# Altium schematic sheet Э3("All Documents",Physical)
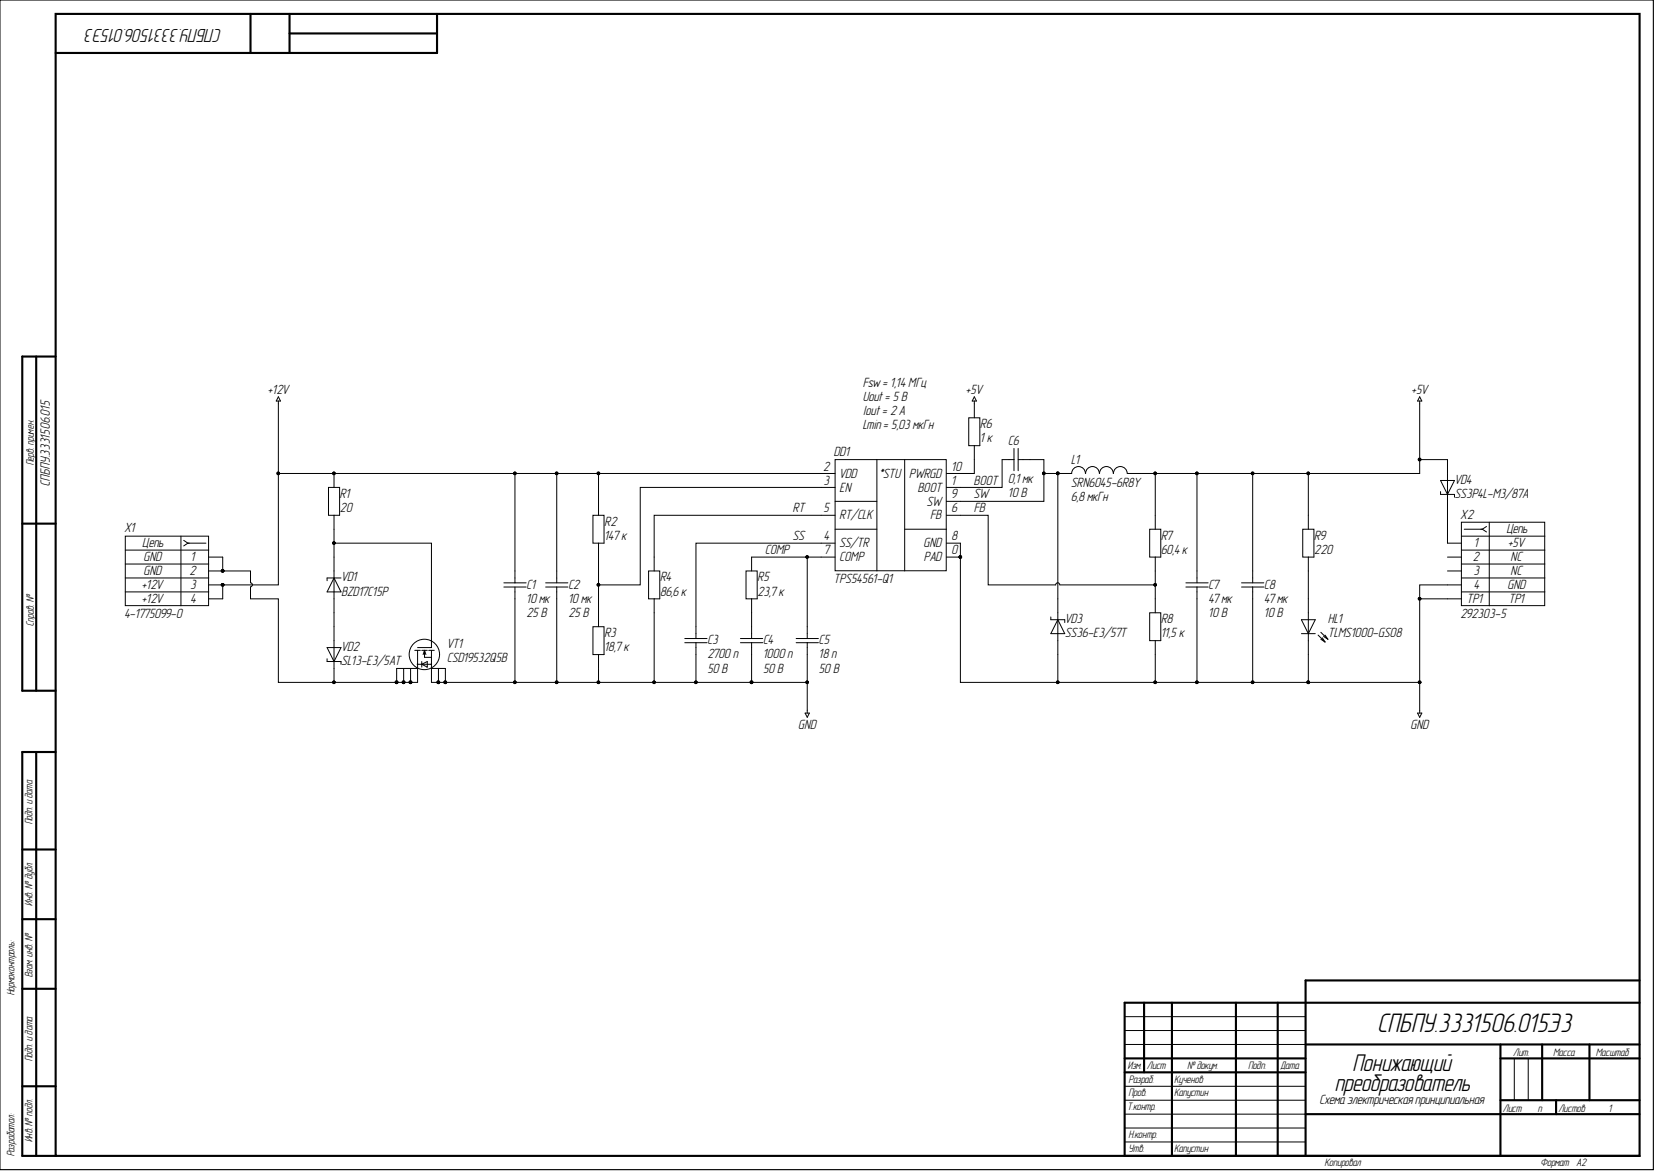
[diagram p0: Э3("All Documents",Physical)]

## Parts
C1  10 мк  [C0805]
C2  10 мк  Номинальное напряжение: 25 В  [C0805]
C3  2700 п  Номинальное напряжение: 50 В  [C0805]
C4  1000 п  Номинальное напряжение: 50 В  [C0402]
C5  18 п  Номинальное напряжение: 50 В  [C0805]
C6  0,1 мк  Номинальное напряжение: 10 В  [C0402]
C7  47 мк  Номинальное напряжение: 10 В  [C1210]
C8  47 мк  Номинальное напряжение: 10 В  [C1210]
DD1  TPS54561-Q1  [WSON-10]
HL1  TLMS1000-GS08  [HL0603]
L1  SRN6045-6R8Y  Номинал: 6,8 мкГн  [SRN6045-6R8Y]
R1  20  [R0402]
R2  147 к  [R0603]
R3  18,7 к  [R0402]
R4  86,6 к  [R0201]
R5  23,7 к  [R0201]
R6  1 к  [R0402]
R7  60,4 к  [R0402]
R8  11,5 к  [R0402]
R9  220  [R0603]
VD1  BZD17C15P  [DO-219AB]
VD2  SL13-E3/5AT  [DO-214AC]
VD3  SS36-E3/57T  [DO-214AB]
VD4  SS3P4L-M3/87A  [TO-277A]
VT1  CSD19532Q5B  [SON-8]
X1  4-1775099-0  Contact_4: +12V  Contact_2: GND  [4-1775099-0]
X2  292303-5  Contact_4: GND  Contact_3: NC  Contact_1: +5V  [292303-5]

## Nets
+5V: C7.1 C8.1 L1.2 R6.2 R7.2 R9.2 VD4.2
+12V: C1.2 C2.1 DD1.2(VDD) R1.2 R2.2 X1.3 X1.4
GND: C1.1 C2.2 C3.2 C4.2 C5.2 C7.2 C8.2 DD1.0(PAD) DD1.8(GND) HL1.1 R3.1 R4.1 R8.1 VD3.2 VT1.S X2.4 X2.TP1
BOOT: C6.2 DD1.1(BOOT)
COMP: C5.1 DD1.7(COMP) R5.2
FB: DD1.6(FB) R7.1 R8.2
RT: DD1.5(RT/CLK) R4.2
SS: C3.1 DD1.4(SS/TR)
SW: C6.1 DD1.9(SW) L1.1 VD3.1
NetC4_1: C4.1 R5.1
NetDD1_3: DD1.3(EN) R2.1 R3.2
NetDD1_10: DD1.10(PWRGD) R6.1
NetHL1_2: HL1.2 R9.1
NetR1_1: R1.1 VD1.1 VT1.G
NetVD1_2: VD1.2 VD2.2
NetVD2_1: VD2.1 VT1.D X1.1 X1.2
NetVD4_1: VD4.1 X2.1
NetX2_2: X2.2
NetX2_3: X2.3

## Library data of the parts
C1 = c0119: =Описание; Фамилия: Кученов; Температурный коэффициент емкости: X5R; Рабочие температуры: от –55 °С до +85 °С; Производитель: Murata Manufacturing Co., Ltd.; Отклонение: ±20 %; Описание: Конденсатор чип 0805 10 мкФ 25 В ±20 %; Номинальное напряжение: 25 В; Наименование: GRM21BR61E106MA73L; Корпус: 0805; Database Table Name: Конденсаторы$; Номинал: 10 мк; Напряжение: 25 В; ID: c0119; HelpURL: https://search.murata.co.jp/Ceramy/image/img/A01X/G101/ENG/GRM21BR61E106MA73-01.pdf; Description: Конденсатор чип 0805 10 мкФ 25 В ±20 %; Component Kind: Standard; Library Name: Database_Students.DbLib
C2 = c0119: =Описание; Фамилия: Кученов; Температурный коэффициент емкости: X5R; Рабочие температуры: от –55 °С до +85 °С; Производитель: Murata Manufacturing Co., Ltd.; Отклонение: ±20 %; Описание: Конденсатор чип 0805 10 мкФ 25 В ±20 %; Наименование: GRM21BR61E106MA73L; Корпус: 0805; Database Table Name: Конденсаторы$; Номинал: 10 мк; Напряжение: 25 В; ID: c0119; HelpURL: https://search.murata.co.jp/Ceramy/image/img/A01X/G101/ENG/GRM21BR61E106MA73-01.pdf; Description: Конденсатор чип 0805 10 мкФ 25 В ±20 %; Component Kind: Standard; Library Name: Database_Students.DbLib
C3 = c0120: =Описание; Фамилия: Кученов; Температурный коэффициент емкости: C0G; Рабочие температуры: от –55 °С до +125 °С; Производитель: TDK Corporation; Отклонение: ±5 %; Описание: Конденсатор чип 0805 2,7 нФ 50 В ±5 %; Наименование: C2012C0G1H272J060AA; Корпус: 0805; Database Table Name: Конденсаторы$; Номинал: 2700 п; Напряжение: 50 В; ID: c0120; HelpURL: https://product.tdk.com/en/search/capacitor/ceramic/mlcc/info?part_no=C2012C0G1H272J060AA; Description: Конденсатор чип 0805 2,7 нФ 50 В ±5 %; Component Kind: Standard; Library Name: Database_Students.DbLib
C4 = c0121: =Описание; Фамилия: Кученов; Температурный коэффициент емкости: C0G; Рабочие температуры: от –55 °С до +125 °С; Производитель: Murata Manufacturing Co., Ltd.; Отклонение: ±5 %; Описание: Конденсатор чип 0402 1 нФ 50 В ±5 %; Наименование: GRM1555C1H102JA01J; Корпус: 0402; Database Table Name: Конденсаторы$; Номинал: 1000 п; Напряжение: 50 В; ID: c0121; HelpURL: https://www.murata.com/en-eu/products/productdetail?partno=GRM1555C1H102JA01%23; Description: Конденсатор чип 0402 1 нФ 50 В ±5 %; Component Kind: Standard; Library Name: Database_Students.DbLib
C5 = c0122: =Описание; Фамилия: Кученов; Температурный коэффициент емкости: C0G; Рабочие температуры: от –55 °С до +125 °С; Производитель: Samsung electro-mechanics; Отклонение: ±5 %; Описание: Конденсатор чип 0805 18 пФ 50 В ±5 %; Наименование: CL21C180JBANNNC; Корпус: 0805; Database Table Name: Конденсаторы$; Номинал: 18 п; Напряжение: 50 В; ID: c0122; HelpURL: https://datasheet.lcsc.com/szlcsc/1810191212_Samsung-Electro-Mechanics-CL21C180JBANNNC_C170109.pdf; Description: Конденсатор чип 0805 18 пФ 50 В ±5 %; Component Kind: Standard; Library Name: Database_Students.DbLib
C6 = c0123: =Описание; Фамилия: Кученов; Температурный коэффициент емкости: X7R; Рабочие температуры: от –55 °С до +125 °С; Производитель: Murata Manufacturing Co., Ltd.; Отклонение: ±15 %; Описание: Конденсатор чип 0402 100 нФ 10 В ±15 %; Наименование: GRM155R71A104KA01D; Корпус: 0402; Database Table Name: Конденсаторы$; Номинал: 0,1 мк; Напряжение: 10 В; ID: c0123; HelpURL: https://www.murata.com/products/productdetail?partno=GRM155R71A104KA01%23; Description: Конденсатор чип 0402 100 нФ 10 В ±15 %; Component Kind: Standard; Library Name: Database_Students.DbLib
C7 C8 = c0124: =Описание; Фамилия: Кученов; Температурный коэффициент емкости: X7R; Рабочие температуры: от –55 °С до +125 °С; Производитель: Murata Manufacturing Co., Ltd.; Отклонение: ±15 %; Описание: Конденсатор чип 1210 47 мкФ 10 В ±15 %; Наименование: GRM32ER71A476KE15; Корпус: 1210; Database Table Name: Конденсаторы$; Номинал: 47 мк; Напряжение: 10 В; ID: c0124; HelpURL: https://www.murata.com/en-global/products/productdetail?partno=GRM32ER71A476KE15%23; Description: Конденсатор чип 1210 47 мкФ 10 В ±15 %; Component Kind: Standard; Library Name: Database_Students.DbLib
DD1 = dd0009: =Описание; Частота переключения: от 100 кГц до 2,5 МГц; Фамилия: Кученов; Рабочие температуры: от -40 °С до +125 °С; Производитель: Texas Instruments; Описание: Понижающий преобразователь напряжения 60 В и 5 А; Коммутируемый ток: до 5 А; Выходное напряжение: от 0,8 В до 59 В; Входное напряжение: от 4,5 В до 60 В; Наименование: TPS54561-Q1; Корпус: WSON-10; Library Name: TPS54561.SchLib; ID: dd0009; HelpURL: https://www.ti.com/lit/ds/symlink/tps54561-q1.pdf?ts=1610208376292&ref_url=https%253A%252F%252Fwww.ti.com%252Fproduct%252FTPS54561-Q1; Description: Понижающий преобразователь напряжения 60 В и 5 А; Component Kind: Standard
HL1 = hl0001: =Описание; Цвет: Red; Фамилия: Ларионов; Рассеиваемая мощность: 40 мВт; Рабочие температуры: от –40 °С до +100 °С; Производитель: Vishay Intertechnology, Inc.; Описание: Светодиод чип 0603 красный; Номинальный прямой ток: 15 мА; Номинальное падение напряжения: 1,8 В; Номинальная сила света: 4 мкд; Номинальная длина волны: 628 нм; Корпус: 0603; Database Table Name: Светодиоды$; Наименование: TLMS1000-GS08; ID: hl0001; HelpURL: https://www.vishay.com/leds/list/product-83172/; Description: Светодиод чип 0603 красный; Component Kind: Standard; Library Name: Database_Students.DbLib
L1 = l0006: =Описание; Фамилия: Кученов; Ток насыщения: 3,8 А; Резонансная частота: 20МГц; Рабочие температуры: от –40 °С до +125 °С; Рабочее напряжение: не указано; Производитель: Bourns, Inc.; Отклонение: ±30 %; Описание: Катушка индуктивности 6,8 мкГн ±30 %; Номинальный ток (при нагреве на 20 °С/40 °С): 2,8 А; Номинальное активное сопротивление: 47,3 мОм; Корпус: 6,0 x 6,0 x 4,5; Database Table Name: Катушки индуктивности$; Наименование: SRN6045-6R8Y; Максимальное активное сопротивление: 47,3 мОм; Индуктивность: 6,8 мкГн; ID: l0006; HelpURL: https://www.bourns.com/data/global/pdfs/SRN6045.pdf; Description: Катушка индуктивности 6,8 мкГн ±30 %; Component Kind: Standard; Library Name: Database_Students.DbLib
R1 = r0135: =Описание; Фамилия: Кученов; Рабочие температуры: от –55 °С до +155 °С; Производитель: YAGEO Corp.; Отклонение: ±1 %; Описание: Резистор чип 0402 20 Ом ±1 % 0,0625 Вт; Номинальный ток: 1 А; Номинальное напряжение: 50 В; Наименование: RC0402FR-0718K7L; Корпус: 0402; Database Table Name: Резисторы$; Номинал: 20; ID: r0135; HelpURL: https://www.yageo.com/upload/media/product/productsearch/datasheet/rchip/PYu-RC_Group_51_RoHS_L_11.pdf; Description: Резистор чип 0402 20 Ом ±1 % 0,0625 Вт; Component Kind: Standard; Library Name: Database_Students.DbLib
R2 = r0100: =Описание; Фамилия: Жернаков; Рабочие температуры: от –55 °С до +155 °С; Производитель: YAGEO Corp.; Отклонение: ±1 %; Описание: Резистор чип 0603 147 кОм ±1 % 0,1 Вт; Номинальный ток: 1 А; Номинальное напряжение: 75 В; Наименование: RC0603FR-07147KL; Корпус: 0603; Database Table Name: Резисторы$; Номинал: 147 к; ID: r0100; HelpURL: https://www.yageo.com/upload/media/product/productsearch/datasheet/rchip/PYu-RC_Group_51_RoHS_L_11.pdf; Description: Резистор чип 0603 147 кОм ±1 % 0,1 Вт; Component Kind: Standard; Library Name: Database_Students.DbLib
R3 = r0134: =Описание; Фамилия: Кученов; Рабочие температуры: от –55 °С до +155 °С; Производитель: YAGEO Corp.; Отклонение: ±1 %; Описание: Резистор чип 0402 18,7 кОм ±1 % 0,0625 Вт; Номинальный ток: 1 А; Номинальное напряжение: 50 В; Наименование: RC0402FR-0718K7L; Корпус: 0402; Database Table Name: Резисторы$; Номинал: 18,7 к; ID: r0134; HelpURL: https://www.yageo.com/upload/media/product/productsearch/datasheet/rchip/PYu-RC_Group_51_RoHS_L_11.pdf; Description: Резистор чип 0402 18,7 кОм ±1 % 0,0625 Вт; Component Kind: Standard; Library Name: Database_Students.DbLib
R4 = r0129: =Описание; Фамилия: Кученов; Рабочие температуры: от –55 °С до +125 °С; Производитель: YAGEO Corp.; Отклонение: ±1 %; Описание: Резистор чип 0201 86,6 кОм ±1 % 0,05 Вт; Номинальный ток: 0.5 А; Номинальное напряжение: 25 В; Наименование: RC0201FR-0786K6L; Корпус: 0201; Database Table Name: Резисторы$; Номинал: 86,6 к; ID: r0129; HelpURL: https://www.yageo.com/upload/media/product/productsearch/datasheet/rchip/PYu-RC_Group_51_RoHS_L_11.pdf; Description: Резистор чип 0201 86,6 кОм ±1 % 0,05 Вт; Component Kind: Standard; Library Name: Database_Students.DbLib
R5 = r0131: =Описание; Фамилия: Кученов; Рабочие температуры: от –55 °С до +125 °С; Производитель: YAGEO Corp.; Отклонение: ±1 %; Описание: Резистор чип 0201 23,7 кОм ±1 % 0,05 Вт; Номинальный ток: 0.5 А; Номинальное напряжение: 25 В; Наименование: RC0201FR-0723K7L; Корпус: 0201; Database Table Name: Резисторы$; Номинал: 23,7 к; ID: r0131; HelpURL: https://www.yageo.com/upload/media/product/productsearch/datasheet/rchip/PYu-RC_Group_51_RoHS_L_11.pdf; Description: Резистор чип 0201 23,7 кОм ±1 % 0,05 Вт; Component Kind: Standard; Library Name: Database_Students.DbLib
R6 = r0130: =Описание; Фамилия: Кученов; Рабочие температуры: от –55 °С до +155 °С; Производитель: Vishay Intertechnology, Inc.; Отклонение: ±1 %; Описание: Резистор чип 0402 1 кОм ±1 % 0,063 Вт; Номинальный ток: 1.5 А; Номинальное напряжение: 50 В; Наименование: CRCW04021K00FKED; Корпус: 0402; Database Table Name: Резисторы$; Номинал: 1 к; ID: r0130; HelpURL: https://www.vishay.com/docs/20035/dcrcwe3.pdf; Description: Резистор чип 0402 1 кОм ±1 % 0,063 Вт; Component Kind: Standard; Library Name: Database_Students.DbLib
R7 = r0132: =Описание; Фамилия: Кученов; Рабочие температуры: от –55 °С до +155 °С; Производитель: Vishay Intertechnology, Inc.; Отклонение: ±1 %; Описание: Резистор чип 0402 60,4 кОм ±1 % 0,063 Вт; Номинальный ток: 1.5 А; Номинальное напряжение: 50 В; Наименование: CRCW040260K4FKED; Корпус: 0402; Database Table Name: Резисторы$; Номинал: 60,4 к; ID: r0132; HelpURL: https://www.vishay.com/docs/20035/dcrcwe3.pdf; Description: Резистор чип 0402 60,4 кОм ±1 % 0,063 Вт; Component Kind: Standard; Library Name: Database_Students.DbLib
R8 = r0133: =Описание; Фамилия: Кученов; Рабочие температуры: от –55 °С до +155 °С; Производитель: Vishay Intertechnology, Inc.; Отклонение: ±1 %; Описание: Резистор чип 0402 11,5 кОм ±1 % 0,063 Вт; Номинальный ток: 1.5 А; Номинальное напряжение: 50 В; Наименование: CRCW040211K5FKED; Корпус: 0402; Database Table Name: Резисторы$; Номинал: 11,5 к; ID: r0133; HelpURL: https://www.vishay.com/docs/20035/dcrcwe3.pdf; Description: Резистор чип 0402 11,5 кОм ±1 % 0,063 Вт; Component Kind: Standard; Library Name: Database_Students.DbLib
R9 = r0009: =Описание; Фамилия: Ларионов; Рабочие температуры: от -55 °С до +155 °С; Производитель: YAGEO Corp.; Отклонение: ±1 %; Описание: Резистор чип 0603 220 Ом ±1 % 0,1 Вт; Номинальный ток: 1 А; Номинальное напряжение: 75 В; Наименование: RC0603FR-07220RL; Корпус: 0603; Database Table Name: Резисторы$; Номинал: 220; ID: r0009; HelpURL: https://www.yageo.com/upload/media/product/productsearch/datasheet/rchip/PYu-RC_Group_51_RoHS_L_11.pdf; Description: Резистор чип 0603 220 Ом ±1 % 0,1 Вт; Component Kind: Standard; Library Name: Database_Students.DbLib
VD1 = vd0016: =Описание; Фамилия: Жернаков; Разброс напряжения стабилизации: 13,8...15,6 В; Рабочие температуры: от –55 °С до +150 °С; Производитель: Vishay Intertechnology, Inc.; Описание: Стабилитрон 15 В; Номинальный ток стабилизации: 50 мА; Номинальное напряжение стабилизации: 15 В; Database Table Name: Стабилитроны$; Наименование: BZD17C15P; Корпус: DO-219AB; ID: vd0016; HelpURL: https://www.vishay.com/product?docid=85161; Description: Стабилитрон 15 В; Component Kind: Standard; Library Name: Database_Students.DbLib
VD2 = vd0002: =Описание; Фамилия: Ларионов; Рабочие температуры: от –55 °С до +125 °С; Производитель: Vishay Intertechnology, Inc.; Пиковое прямое напряжение: 0,445 В; Описание: Диод Шоттки 30 В 1,5 А; Макс допустимый средний прямой ток: 1,5 А; Импульсное обратное напряжение: 30 В; Database Table Name: Диоды$; Наименование: SL13-E3/5AT; Корпус: DO-214AC; ID: vd0002; HelpURL: https://www.vishay.com/product?docid=88740; Description: Диод Шоттки 30 В 1,5 А; Component Kind: Standard; Library Name: Database_Students.DbLib
VD3 = vd0004: =Описание; Фамилия: Жернаков; Рабочие температуры: от –55 °С до +150 °С; Производитель: Vishay Intertechnology, Inc.; Пиковое прямое напряжение: 0,75 В; Описание: Диод Шоттки 60 В 3 А; Макс допустимый средний прямой ток: 3 А; Импульсное обратное напряжение: 60 В; Database Table Name: Диоды$; Наименование: SS36-E3/57T; Корпус: DO-214AB; ID: vd0004; HelpURL: https://www.vishay.com/product?docid=88751; Description: Диод Шоттки 60 В 3 А; Component Kind: Standard; Library Name: Database_Students.DbLib
VD4 = vd0003: =Описание; Фамилия: Ларионов; Рабочие температуры: от –55 °С до +150 °С; Производитель: Vishay Intertechnology, Inc.; Пиковое прямое напряжение: 0,47 В; Описание: Диод Шоттки 40 В 3 А; Макс допустимый средний прямой ток: 3 А; Импульсное обратное напряжение: 40 В; Database Table Name: Диоды$; Наименование: SS3P4L-M3/87A; Корпус: TO-277A; ID: vd0003; HelpURL: https://www.vishay.com/diodes/list/product-88986/; Description: Диод Шоттки 40 В 3 А; Component Kind: Standard; Library Name: Database_Students.DbLib
VT1 = vt0001: =Описание; Фамилия: Жернаков; Ток стока: 17 А; Сопротивление сток-исток: 0,0057 Ом; Рабочие температуры: от -55 °С до +150 °С; Производитель: Texas Instruments; Описание: Транзистор полевой N-канальный 100 В 17 А; Напряжение сток-исток: 100 В; Напряжение затвор-исток: ±20 В; Database Table Name: Транзисторы$; Наименование: CSD19532Q5B; Корпус: SON-8; ID: vt0001; HelpURL: https://www.ti.com/product/CSD19532Q5B?keyMatch=CSD19532Q5B&tisearch=Search-EN-everything&usecase=OPN; Description: Транзистор полевой N-канальный 100 В 17 А; Component Kind: Standard; Library Name: Database_Students.DbLib
X1 = x0004: =Описание; Фамилия: Ларионов; Производитель: TE Connectivity; Описание: ATX Power 4 pin гнездо прямой; Database Table Name: Разъемы$; Наименование: 4-1775099-0; ID: x0004; HelpURL: https://www.te.com/usa-en/product-4-1775099-0.html; Description: ATX Power 4 pin гнездо прямой; Contact_3: +12V; Contact_1: GND; Component Kind: Standard; Library Name: Database_Students.DbLib
X2 = x0003: =Описание; Фамилия: Ларионов; Производитель: TE Connectivity; Описание: USB 2.0 Type A гнездо; Database Table Name: Разъемы$; Наименование: 292303-5; ID: x0003; HelpURL: https://www.te.com/usa-en/product-292303-5.html; Description: USB 2.0 Type A гнездо; Contact_2: NC; Component Kind: Standard; Library Name: Database_Students.DbLib
